# Altium schematic sheet pt100.SchDoc
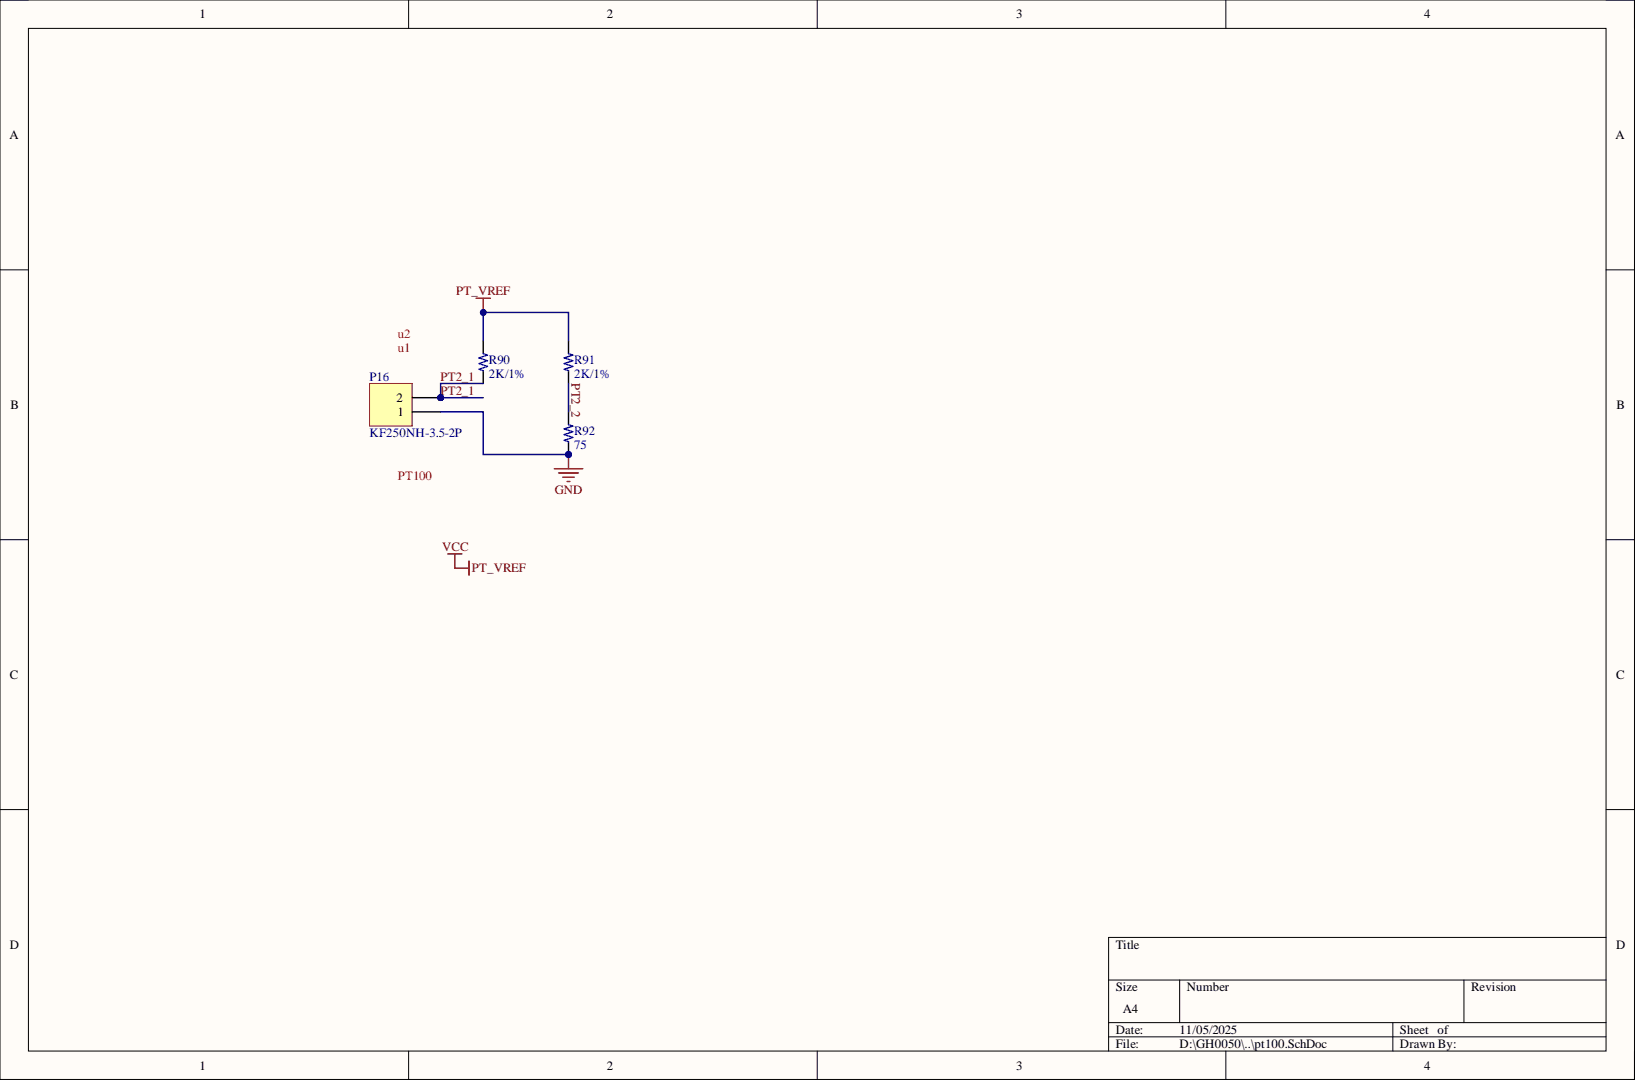
[diagram p0: pt100.SchDoc]

## Parts
P16  KF250NH-3.5-2P  [KF250NH-3.5-2P]
R90  2K/1%  [0603R]
R91  2K/1%  [0603R]
R92  75  [0603R]

## Nets
GND: P16.1 R92.1
PT_VREF: R90.2 R91.2
PT2_1: P16.2 R90.1
PT2_2: R91.1 R92.2

## Library data of the parts
P16 = Header 2: Component Kind: Standard; Library Name: Miscellaneous Connectors.IntLib; Signal Integrity: Connector; Value: Header 2; Publisher: Altium Limited
R90-R92 = R: Component Kind: Standard; Library Name: JRJ_1.SCHLIB
  R90 R91: Value: 2K/1%
  R92: Value: 75
